# Altium schematic sheet Power.SchDoc(Power)
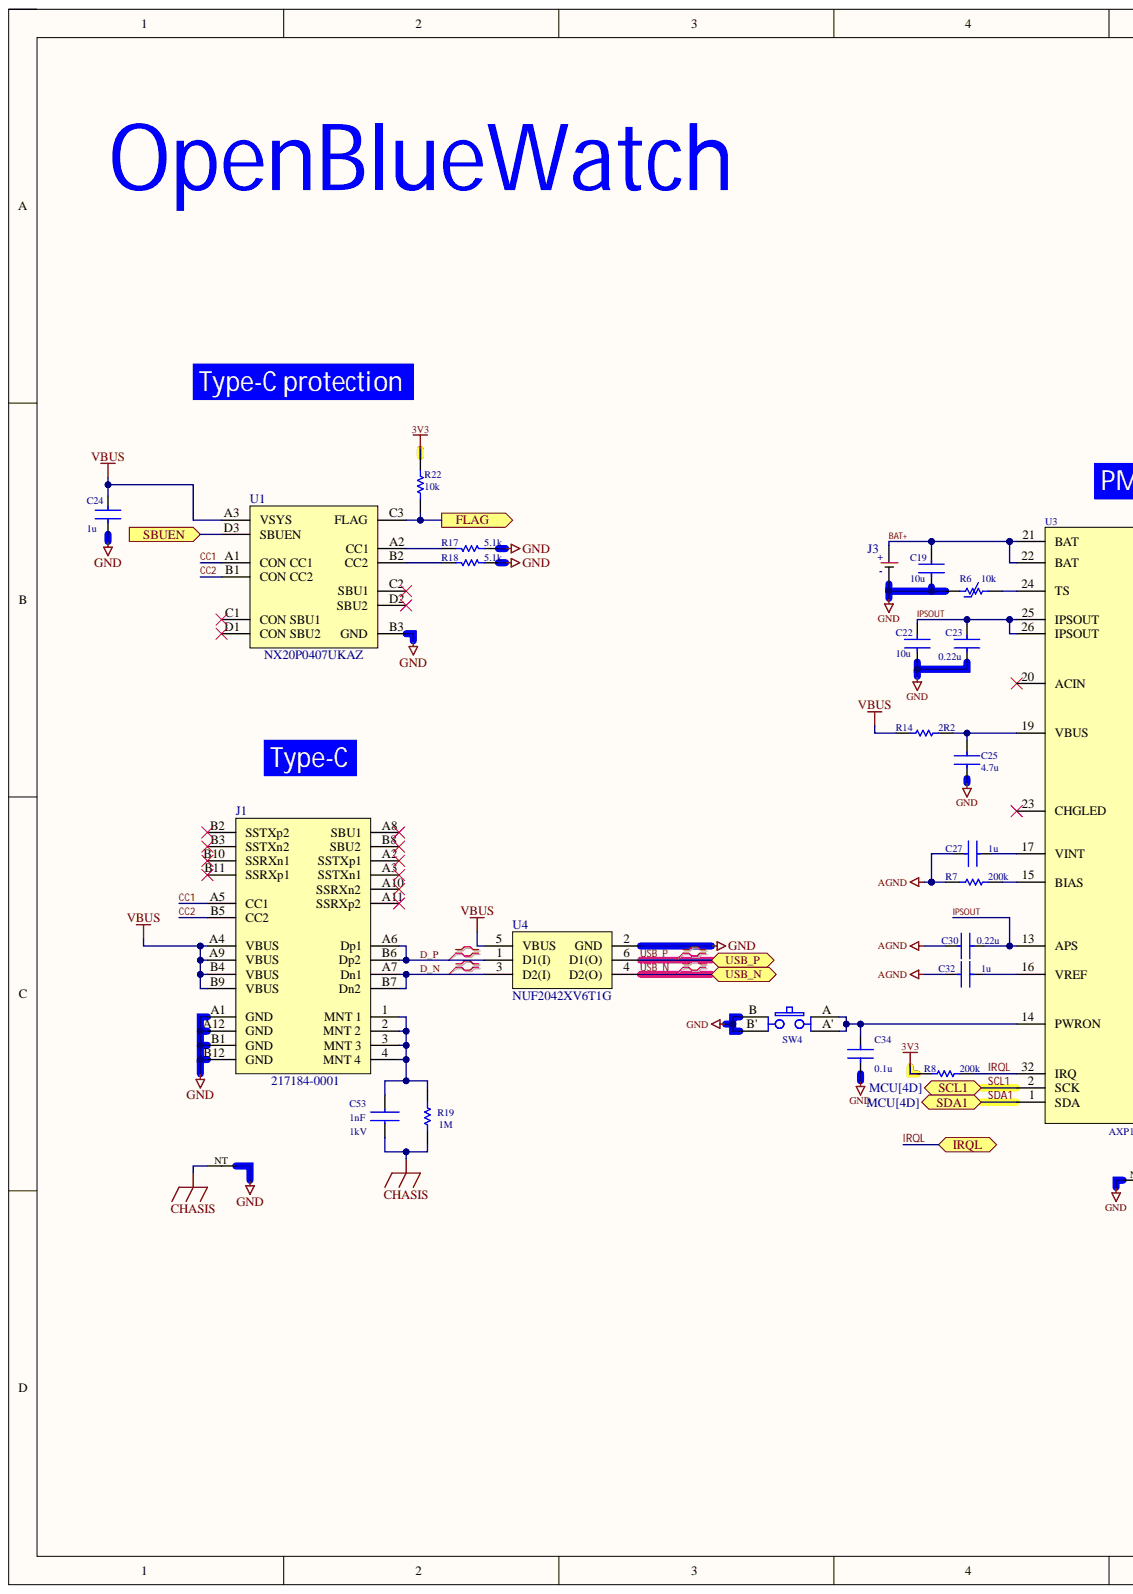
[diagram p0: Power.SchDoc, left]
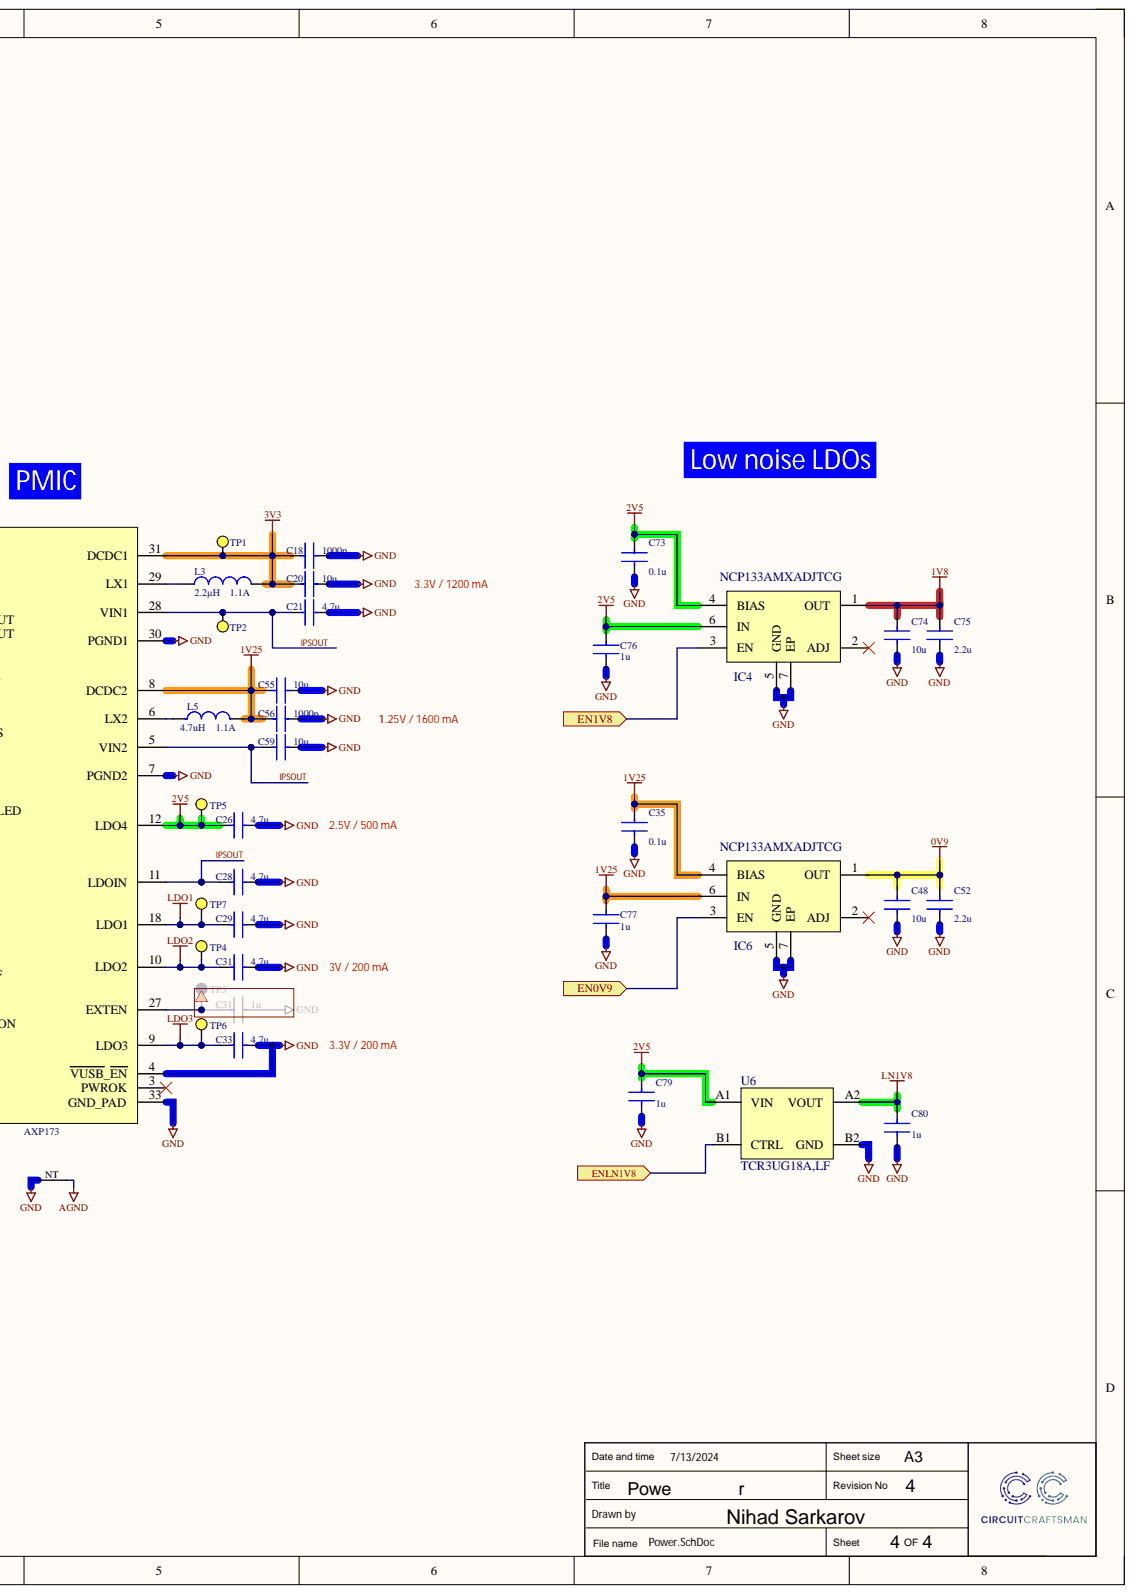
[diagram p1: Power.SchDoc, right]

## Parts
C18  Capacitance: 1000p  [FP-C1608-080-0_1-MFG]  (hidden comment: C1608X7R1E105K080AB)
C19  Capacitance: 10u  [FP-C1608-080-0_1-MFG]  (hidden comment: C1608X7R1E105K080AB)
C20  Capacitance: 10u  [FP-C1608-080-0_1-MFG]  (hidden comment: C1608X7R1E105K080AB)
C21  Capacitance: 4.7u  [FP-C1608-080-0_1-MFG]  (hidden comment: C1608X7R1E105K080AB)
C22  Capacitance: 10u  [FP-C1608-080-0_1-MFG]  (hidden comment: C1608X7R1E105K080AB)
C23  Capacitance: 0.22u  [FP-C1608-080-0_1-MFG]  (hidden comment: C1608X7R1E105K080AB)
C24  Capacitance: 1u  [FP-C1608-080-0_1-MFG]  (hidden comment: C1608X7R1E105K080AB)
C25  Capacitance: 4.7u  [FP-C1608-080-0_1-MFG]  (hidden comment: C1608X7R1E105K080AB)
C26  Capacitance: 4.7u  [FP-C1608-080-0_1-MFG]  (hidden comment: C1608X7R1E105K080AB)
C27  Capacitance: 1u  [FP-C1608-080-0_1-MFG]  (hidden comment: C1608X7R1E105K080AB)
C28  Capacitance: 4.7u  [FP-C1608-080-0_1-MFG]  (hidden comment: C1608X7R1E105K080AB)
C29  Capacitance: 4.7u  [FP-C1608-080-0_1-MFG]  (hidden comment: C1608X7R1E105K080AB)
C30  Capacitance: 0.22u  [FP-C1608-080-0_1-MFG]  (hidden comment: C1608X7R1E105K080AB)
C31  Capacitance: 4.7u  [FP-C1608-080-0_1-MFG]  (hidden comment: C1608X7R1E105K080AB)
C32  Capacitance: 1u  [FP-C1608-080-0_1-MFG]  (hidden comment: C1608X7R1E105K080AB)
C33  Capacitance: 4.7u  [FP-C1608-080-0_1-MFG]  (hidden comment: C1608X7R1E105K080AB)
C34  value 0.1u  Capacitance: 0.1u  [FP-CC0402-IPC_C]  (hidden comment: CC0402KRX7R7BB104)
C35  value 0.1u  Capacitance: 0.1u  [FP-CC0402-IPC_C]  (hidden comment: CC0402KRX7R7BB104)
C48  Capacitance: 10u  [FP-C1608-080-0_1-MFG]  (hidden comment: C1608X7R1E105K080AB)
C52  Capacitance: 2.2u  [FP-C1608-080-0_1-MFG]  (hidden comment: C1608X7R1E105K080AB)
C53  Capacitance: 1nF  Voltage - Rated: 1kV  [CAPC1608X90N]  (hidden comment: C0603V102KDRAC7867)
C55  Capacitance: 10u  [FP-C1608-080-0_1-MFG]  (hidden comment: C1608X7R1E105K080AB)
C56  Capacitance: 1000p  [FP-C1608-080-0_1-MFG]  (hidden comment: C1608X7R1E105K080AB)
C59  Capacitance: 10u  [FP-C1608-080-0_1-MFG]  (hidden comment: C1608X7R1E105K080AB)
C73  value 0.1u  Capacitance: 0.1u  [FP-CC0402-IPC_C]  (hidden comment: CC0402KRX7R7BB104)
C74  Capacitance: 10u  [FP-C1608-080-0_1-MFG]  (hidden comment: C1608X7R1E105K080AB)
C75  Capacitance: 2.2u  [FP-C1608-080-0_1-MFG]  (hidden comment: C1608X7R1E105K080AB)
C76  Capacitance: 1u  [FP-C1608-080-0_1-MFG]  (hidden comment: C1608X7R1E105K080AB)
C77  Capacitance: 1u  [FP-C1608-080-0_1-MFG]  (hidden comment: C1608X7R1E105K080AB)
C79  value 1u  Capacitance: 1u  [FP-CC0402-IPC_C]  (hidden comment: CC0402KRX7R7BB104)
C80  value 1u  Capacitance: 1u  [FP-CC0402-IPC_C]  (hidden comment: CC0402KRX7R7BB104)
IC4  NCP133AMXADJTCG  [NCP133AMX110TCG]
IC6  NCP133AMXADJTCG  [NCP133AMX110TCG]
J1  217184-0001  [FP-217184-0001-MFG]
J3  Battery connector  [JST-PH 2.0MM]  (value not drawn)
L3  Current - Saturation: 1.1A  Inductance: 2.2µH  [INDC2013X140X50LL20T20]  (hidden comment: BRC2012T2R2MD)
L5  Current Rating: 1.1A  Inductance: 4.7uH  [FP-LQM2MPN_G0-MFG]  (hidden comment: LQM2MPN4R7MG0L)
Nt1  Net tie  [Net tie]  (value not drawn)
Nt2  Net tie  [Net tie]  (value not drawn)
R6  Resistance: 10k  [FP-NTSD1_FPB30-MFG]  (hidden comment: NTSD1XH103FPB30)
R7  Resistance: 200k  [RESC1005X40X25LL05T05]  (hidden comment: CRCW040222R0FKED)
R8  Resistance: 200k  [RESC0603X28X15LL5T05]  (hidden comment: CRCW02010000Z0ED)
R14  Resistance: 2R2  [RESC1005X40X25LL05T05]  (hidden comment: CRCW040222R0FKED)
R17  Resistance: 5.1k  [RESC0603X28X15LL5T05]  (hidden comment: CRCW02010000Z0ED)
R18  Resistance: 5.1k  [RESC0603X28X15LL5T05]  (hidden comment: CRCW02010000Z0ED)
R19  Resistance: 1M  [RESC1005X40X25ML05T05]  (hidden comment: ERJ-2GEJ105X)
R22  Resistance: 10k  [RESC0603X28X15LL5T05]  (hidden comment: CRCW02010000Z0ED)
SW4  EVP-BB2A9B000  [FP-EVP-BB2A9B000-MFG]  (value not drawn)
TP1  Test point  [Test point]  (value not drawn)
TP2  Test point  [Test point]  (value not drawn)
TP4  Test point  [Test point]  (value not drawn)
TP5  Test point  [Test point]  (value not drawn)
TP6  Test point  [Test point]  (value not drawn)
TP7  Test point  [Test point]  (value not drawn)
U1  NX20P0407UKAZ  [FP-SOT1390-7-IPC_A]
U3  AXP173  [QFN40P400X400X55-33N]
U4  NUF2042XV6T1G  [FP-463A-MFG]
U6  TCR3UG18A,LF  [FP-WCSP4F-IPC_C]

## Ports
EN0V9, EN1V8, ENLN1V8, FLAG, IRQL, SBUEN, SCL1, SDA1, USB_N, USB_P

## Nets
0V9: C48.1 C52.1 IC6.1(OUT)
1V8: C74.1 C75.1 IC4.1(OUT)
1V25: C35.1 C55.1 C56.1 C77.1 IC6.4(BIAS) IC6.6(IN) L5.2 U3.8(DCDC2)
2V5: C26.1 C73.1 C76.1 C79.1 IC4.4(BIAS) IC4.6(IN) TP5.1 U3.12(LDO4) U6.A1(VIN)
3V3: C18.1 C20.1 L3.2 R8.2 R22.1 TP1.1 U3.31(DCDC1)
AGND: C27.2 C30.2 C32.2 Nt1.2 R7.1
CHASIS: C53.1 Nt2.1 R19.1
GND: C18.2 C19.1 C20.2 C21.2 C22.1 C23.1 C24.2 C25.1 C26.2 C28.2 C29.2 C31.2 C33.2 C34.2 C35.2 C48.2 C52.2 C55.2 C56.2 C59.2 C73.2 C74.2 C75.2 C76.2 C77.2 C79.2 C80.2 IC4.5(GND) IC4.7(EP) IC6.5(GND) IC6.7(EP) J1.A1(GND) J1.A12(GND) J1.B1(GND) J1.B12(GND) J3.2 Nt1.1 Nt2.2 R6.1 R17.1 R18.1 SW4.B SW4.B' U1.B3(GND) U3.4(VUSB_EN) U3.7(PGND2) U3.30(PGND1) U3.33(GND_PAD) U4.2(GND) U6.B2(GND)
LDO1: C29.1 TP7.1 U3.18(LDO1)
LDO2: C31.1 TP4.1 U3.10(LDO2)
LDO3: C33.1 TP6.1 U3.9(LDO3)
LN1V8: C80.1 U6.A2(VOUT)
VBUS: C24.1 J1.A4(VBUS) J1.A9(VBUS) J1.B4(VBUS) J1.B9(VBUS) R14.1 U1.A3(VSYS) U4.5(VBUS)
BAT+: C19.2 J3.1 U3.21(BAT) U3.22(BAT)
CC1: J1.A5(CC1) U1.A1(CON_CC1)
CC2: J1.B5(CC2) U1.B1(CON_CC2)
D_N: J1.A7(Dn1) J1.B7(Dn2) U4.3(D2(I))
D_P: J1.A6(Dp1) J1.B6(Dp2) U4.1(D1(I))
EN0V9: IC6.3(EN) ; port EN0V9
EN1V8: IC4.3(EN) ; port EN1V8
ENLN1V8: U6.B1(CTRL) ; port ENLN1V8
FLAG: R22.2 U1.C3(FLAG) ; port FLAG
IPSOUT: C21.1 C22.2 C23.2 C28.1 C30.1 C59.1 TP2.1 U3.5(VIN2) U3.11(LDOIN) U3.13(APS) U3.25(IPSOUT) U3.26(IPSOUT) U3.28(VIN1)
IRQL: R8.1 U3.32(IRQ) ; port IRQL
SBUEN: U1.D3(SBUEN) ; port SBUEN
SCL1: U3.2(SCK) ; port SCL1
SDA1: U3.1(SDA) ; port SDA1
USB_N: U4.4(D2(O)) ; port USB_N
USB_P: U4.6(D1(O)) ; port USB_P
NetC25_2: C25.2 R14.2 U3.19(VBUS)
NetC27_1: C27.1 U3.17(VINT)
NetC32_1: C32.1 U3.16(VREF)
NetC34_1: C34.1 SW4.A SW4.A' U3.14(PWRON)
NetC53_2: C53.2 J1.1(MNT_1) J1.2(MNT_2) J1.3(MNT_3) J1.4(MNT_4) R19.2
NetL3_1: L3.1 U3.29(LX1)
NetL5_1: L5.1 U3.6(LX2)
NetR6_2: R6.2 U3.24(TS)
NetR7_2: R7.2 U3.15(BIAS)
NetR17_2: R17.2 U1.A2(CC1)
NetR18_2: R18.2 U1.B2(CC2)

Not connected: IC4 2(ADJ); IC6 2(ADJ); J1 A2(SSTXp1) A3(SSTXn1) A8(SBU1) A10(SSRXn2) A11(SSRXp2) B2(SSTXp2) B3(SSTXn2) B8(SBU2) B10(SSRXn1) B11(SSRXp1); U1 C1(CON_SBU1) C2(SBU1) D1(CON_SBU2) D2(SBU2); U3 3(PWROK) 20(ACIN) 23(CHGLED) 27(EXTEN)

## Library data of the parts
C18-C33 C48 C52 C55 C56 C59 C74-C77 = CMP-08246-005723-1: Multilayer Ceramic Capacitors 1μF ±10% 25V X7R SMD 0603; Case Code (Imperial): 0603; Case Code (Metric): 1608; Case/Package: 0603; Component Kind: Standard; Construction: Flat; Depth: 0.8mm; Dielectric: X7R; Dielectric Material: Ceramic; Height: 0.8mm; Lead Free: Lead Free; Length: 1.6mm; Library Name: Smart_Watch V4.SchLib; Max Operating Temperature: 125°C; Min Operating Temperature: -55°C; Mount: Surface Mount; Package Quantity: 4000; Packaging: Tape and Reel; Radiation Hardening: No; REACH SVHC: No SVHC; Resistor Type: Ceramic; RoHS Compliant: Yes; Series: C; Termination: SMD/SMT; Thickness: 0.037inch; Tolerance: 10%; Voltage: 25V; Voltage Rating: 25V; Voltage Rating (DC): 25V; Weight: 7.1E-05oz; Width: 0.031inch
C34 C35 C73 C79 C80 = CMP-03422-000048-1: CAP CER  X7R 0402; Manufacturer: YAGEO; Automotive Grade: NA; Material: Ceramic; Temperature Coefficient: 30ppm/°C; Tolerance: 0.1; Voltage Rating: 16 V; Automotive: No; Category: Cap; Component Kind: Standard; ComponentLink2Description: Datasheet; ComponentLink2URL: http://www.yageo.com/documents/recent/UPY-GP_NP0_16V-to-50V_16.pdf; Device Class L1: Passive Components; Device Class L2: Capacitors; Device Class L3: Ceramic Capacitors; Digikey Description: CAP CER 0.1UF 16V X7R 0402; Footprint Url: http://www.yageo.com/exep/pages/download/literatures/UPY-C_GEN_24.pdf; Height: 0.55mm; Ipc Land Pattern Name: CAPC10050X50; Lead Free: Yes; Library Name: Smart_Watch V4.SchLib; Manufacturer Part Number: CC0402KRX7R7BB104; Mouser Description: 0402 0.1 uF 16 V ±10 % Tolerance X7R SMT Multilayer Ceramic Capacitor; Octopart: https://octopart.com/cc0402krx7r7bb104-yageo-42244624; Package: CC0402; RoHS: TRUE; Temperature: -55°C to +125°C; Temperature Characteristic: X7R; Temperature Range High: +125°C; Temperature Range Low: -55°C
C53 = C0603V102KDRAC7867: 1000 pF ±10% 1000V (1kV) Ceramic Capacitor X7R 0603 (1608 Metric); Manufacturer_Name: KEMET; Arrow Part Number: C0603V102KDRAC7867; Arrow Price/Stock: https://www.arrow.com/en/products/c0603v102kdrac7867/kemet-corporation; Component Kind: Standard; Datasheet Link: https://api.kemet.com/component-edge/download/specsheet/C0603V102KDRAC7867.pdf; Height: 0.9mm; Library Name: Smart_Watch V4.SchLib; Manufacturer_Part_Number: C0603V102KDRAC7867; Mouser Part Number: N/A; Mouser Price/Stock: https://www.mouser.co.uk/ProductDetail/KEMET/C0603V102KDRAC7867?qs=sSYV1F9c5cFUkpdTww7WIA%3D%3D; Supplier 1: Digikey; Supplier Part Number 1: 399-12306-1-ND
IC4 IC6 = NCP133AMXADJTCG: Integrated Circuit; Manufacturer_Name: onsemi; Arrow Part Number: NCP133AMXADJTCG; Arrow Price/Stock: https://www.arrow.com/en/products/ncp133amxadjtcg/on-semiconductor?region=nac; Component Kind: Standard; Datasheet Link: http://www.onsemi.com/pub/Collateral/NCP133-D.PDF; Height: 0mm; Library Name: Smart_Watch V4.SchLib; Manufacturer_Part_Number: NCP133AMXADJTCG; Mouser Part Number: 863-NCP133AMXADJTCG; Mouser Price/Stock: https://www.mouser.co.uk/ProductDetail/onsemi/NCP133AMXADJTCG?qs=7lMtFQ21GD%2Fb0CQ5YAVOhw%3D%3D
  IC4: Supplier 1: Avnet; Supplier Part Number 1: NCP133AMXADJTCG
J1 = CMP-04767-000027-1: MID-MOUNT DUAL ROWS SMT 24POS -T; Component Kind: Standard; Library Name: Smart_Watch V4.SchLib
J3 = Battery connector: JST-PH 2.0MM; Component Kind: Standard; Library Name: Smart_Watch V4.SchLib
L3 = CMP-2000-05964-1: Wire-Wound Chip Power Inductor, 2.2 uH, +/- 20%, -40 to 105 degC, 2-Pin SMD (0805), RoHS, Tape and Reel; Manufacturer: Taiyo Yuden; Current Rating: 1A; DC Resistance (DCR): 143mOhmMax; EDA / CAD Models: DownloadfromAcceleratedDesigns; Frequency - Self Resonant: 350MHz; Frequency - Test: 1MHz; Online Catalog: BRSeries; Other Names: 587-2905-2  LQBRC2012T2R2MD; Shielding: Unshielded; Type: Wirewound; Category: Inductors,Coils,Chokes; Component Kind: Standard; Datasheet URL: http://ds.yuden.co.jp/TYCOMPAS/ut/detail.do?productNo=BRC2012T2R2MD&fileName=BRC2012T2R2MD_SS&mode=specSheetDownload; Datasheet Version: 09/2016; Family: FixedInductors; Height - Seated (Max): 0.055"(1.40mm); Library Name: Altium Content Vault; Manufacturer URL: http://www.t-yuden.com/; Mounting Technology: SurfaceMount; Mounting Type: SurfaceMount; Operating Temperature: -40°C~105°C; Package / Case: 0805(2012Metric); Package Description: 2-Pin Surface Mount Device, Body 2 x 1.25 mm; Package Reference: 0805; Packaging: Tape&Reel(TR); Series: BR; Size / Dimension: 0.079"Lx0.049"W(2.00mmx1.25mm); Standard Package: 2,000; Tolerance: ±20%
L5 = CMP-06042-016483-1: Multilayer Type Inductor for Power Lines, 0806 (2016), 4.7μH, 20%, 0.175Ω, 1.1A; Core Material: Ferrite; DC Resistance (DCR): 175mR; Self Resonant Frequency: 20MHz; Shielding: Shielded; Test Frequency: 1MHz; Case Code (Imperial): 0806; Case Code (Metric): 2016; Case/Package: 0805; Component Kind: Standard; DC Current: 1.1A; Depth: 1.75mm; Height: 0.9mm; Height - Seated (Max): 0.039inch; Length: 0.079inch; Library Name: Altium Content Vault; Max DC Current: 1.1A; Max Operating Temperature: 125°C; Military Standard: Not; Min Operating Temperature: -55°C; Mount: Surface Mount; Package Quantity: 3000; Packaging: Tape and Reel; RoHS Compliant: Yes; Termination: SMD/SMT; Tolerance: 20%; Weight: 0.000353oz; Width: 0.049inch
R6 = CMP-06022-000009-1: NTC Thermistors 10kΩ  	±1%  Current  	0.12mA Radial; Max Power Dissipation: 15mW; Component Kind: Standard; ComponentLink1Description: Link; ComponentLink1URL: https://www.digikey.com/en/products/detail/tinycircuits/ASR00003/7404516; Depth: 2mm; Lead Free: Lead Free; Length: 3mm; Library Name: Smart_Watch V4.SchLib; Max Operating Temperature: 125°C; Min Operating Temperature: -40°C; Mount: Through Hole; Number of Pins: 2; Packaging: Bulk; Radiation Hardening: No; Tolerance: 1%
R7 R14 = CMP-2002-08382-1: Manufacturer: Vishay Dale; Component Kind: Standard; Library Name: Altium Content Vault; Part Number: CRCW040222R0FKED
R8 R17 R18 R22 = CMP-2004-00001-2: Chip Resistor, 0 Ohm, 0.05 W, -55 to 155 degC, 0201 (0603 Metric), RoHS, Tape and Reel; Case\Package: 0201; Power Rating: 50 mW; Tolerance: 5%; Voltage Rating: 30 V; Case Code (Imperial): 0201; Case Code (Metric): 0603; Component Kind: Standard; ComponentLink1Description: Manufacturer URL; ComponentLink1URL: http://www.vishay.com/; ComponentLink2Description: Datasheet; ComponentLink2URL: http://www.vishay.com/docs/20052/crcw0201e3.pdf; DatasheetVersion: 03/2016; Height: 0.23 mm; Length: 0.6 mm; Library Name: Smart_Watch V4.SchLib; Max Operating Temperature: 155 degC; Min Operating Temperature: -55 degC; Mount: Surface Mount; Mounting Technology: Surface Mount; Package Quantity: 1; PackageDescription: 2-Pin Surface Mount Device, Body 0.6 x 0.3 mm; PackageReference: 0201; Packaging: Cut Tape; Radiation Hardening: No; REACH SVHC: Unknown; RoHSCompliant: true; Weight: 6E-06 oz; Width: 0.3 mm
R19 = CMP-1011-00146-2: Manufacturer: Panasonic; Component Kind: Standard; Library Name: Altium Content Vault; Part Number: ERJ-2GEJ105X
SW4 = CMP-05618-000013-1: SWITCH TACTILE SPST-NO 0.02A 15V; Manufacturer: Panasonic; Contact Current Rating: 20mA; Contact Resistance: 500mΩ; Electromechanical Life: 500000Cycles; Operating Force: 1.6N; Standoff Height: 0.025mm; Automotive: No; Category: Switch; Component Kind: Standard; ComponentLink2Description: Datasheet; ComponentLink2URL: https://www3.panasonic.biz/ac/e_download/control/switch/light-touch/catalog/sw_lt_eng_2616s.pdf?f_cd=402266&via=ok; Device Class L1: Electromechanical; Device Class L2: Switches; Device Class L3: Tactile Switches; Digikey Description: SWITCH TACTILE SPST-NO 0.02A 15V; Height: 0.63mm; Lead Free: Yes; Library Name: Smart_Watch V4.SchLib; Manufacturer Part Number: EVP-BB2A9B000; Mount: Surface Mount; Octopart: https://octopart.com/evp-bb2a9b000-panasonic-75477754; Package: 0; RoHS: TRUE; Temperature: -40°C to +85°C; Temperature Range High: +85°C; Temperature Range Low: -40°C; Throw Configuration: SPST-NO; Voltage Rating Dc: 15V
TP1 TP2 TP4-TP7 = Test point: 1mm x 1mm round pad for testing purposes; Component Kind: Standard; Library Name: Smart Watch.SchLib
U1 = CMP-10136-000007-1: USB TYPE-C CC1/2 SBU1/2 PROT IC; Component Kind: Standard; Library Name: Smart_Watch V4.SchLib; Supplier 1: Digikey; Supplier Part Number 1: 568-13844-1-ND
U3 = AXP173: AXP173 is a highly integrated power system management chip, targeting single-cell lithium batteries (lithium ion or lithium polymer) and requiring multi-channel power conversion  Output applications provide a complete power solution that is easy to use and can be flexibly configured to fully meet the increasingly complex application processing.; Component Kind: Standard; ComponentLink1Description: Datasheet; ComponentLink1URL: https://www.datasheet-pdf.com/PDF/AXP173-Datasheet-X-Powers-966875; ComponentLink2Description: Supplier; ComponentLink2URL: https://aliexpress.ru/item/32915474138.html?sku_id=10000001886631882&spm=a2g2w.productlist.search_results.0.7dc021d8WYAc3c; Library Name: Smart_Watch V4.SchLib
U4 = CMP-1066-00027-2: RC (Pi) EMI Filter 2nd Order Low Pass 2 Channel R = 22Ohms, C = 42pF (Total) SOT-563, SOT-666; DC Resistance (DCR): 26.4R; Direction: Bidirectional; ESD Protection: Yes; Max Breakdown Voltage: 8V; Max DC Current: 100nA; Number of Channels: 3; Capacitance: 42pF; Case/Package: SOT-563-6; Component Kind: Standard; Contact Plating: Tin; Depth: 1.7mm; Halogen Free: Halogen Free; Height: 0.024inch; Lead Free: Lead Free; Length: 0.063inch; Library Name: Smart_Watch V4.SchLib; Max Operating Temperature: 125°C; Min Operating Temperature: -55°C; Number of Lines: 3; Number of Pins: 6; Package Quantity: 4000; Packaging: Tape and Reel; Radiation Hardening: No; Supplier 1: Future Electronics; Supplier Part Number 1: 4631438; Termination: Gull Wing; Width: 0.047inch
U6 = CMP-35871-000003-1: IC REG LINEAR 1.8V 300MA 4WCSP-F; Manufacturer: Toshiba; Accuracy Percentage: 1%; Dropout Voltage: 335mV; Max Junction Temp: +150°C; Max Power Dissipation: 800mW; Max Supply Voltage: 5.5V; Min Supply Voltage: 1.5V; Nominal Supply Current: 0.34-0.38uA; Number Of Outputs: 1; Output Type: Fixed; Standoff Height: 0.08mm; Automotive: No; Category: IC; Component Kind: Standard; ComponentLink2Description: Datasheet; ComponentLink2URL: https://toshiba.semicon-storage.com/info/docget.jsp?did=59176&prodName=TCR3UG18A; Device Class L1: Integrated Circuits (ICs); Device Class L2: Power Management ICs; Device Class L3: Voltage Regulators - Linear; Digikey Description: IC REG LINEAR 1.8V 300MA 4WCSP-F; Height: 0.33mm; Ipc Land Pattern Name: BGA4N35P2X2_64X64X33; Lead Free: Yes; Library Name: Smart_Watch V4.SchLib; Manufacturer Part Number: TCR3UG18A,LF; Octopart: https://octopart.com/tcr3ug18a%2Clf-toshiba-87552120; Output Current: 300mA; Output Voltage: 1.8V; Package: WCSP4F; RoHS: TRUE; Supplier 1: Avnet; Supplier Part Number 1: TCR3UG18A,LF; Temperature: -40°C to +85°C; Temperature Range High: +85°C; Temperature Range Low: -40°C
Nt1 Nt2 = Net Tie: Component Kind: Net Tie; Description: Net tie; Library Name: Smart_Watch V4.SchLib
